# Altium schematic sheet [5] Peripheral_Module.SchDoc("[5] Peripheral_Module")
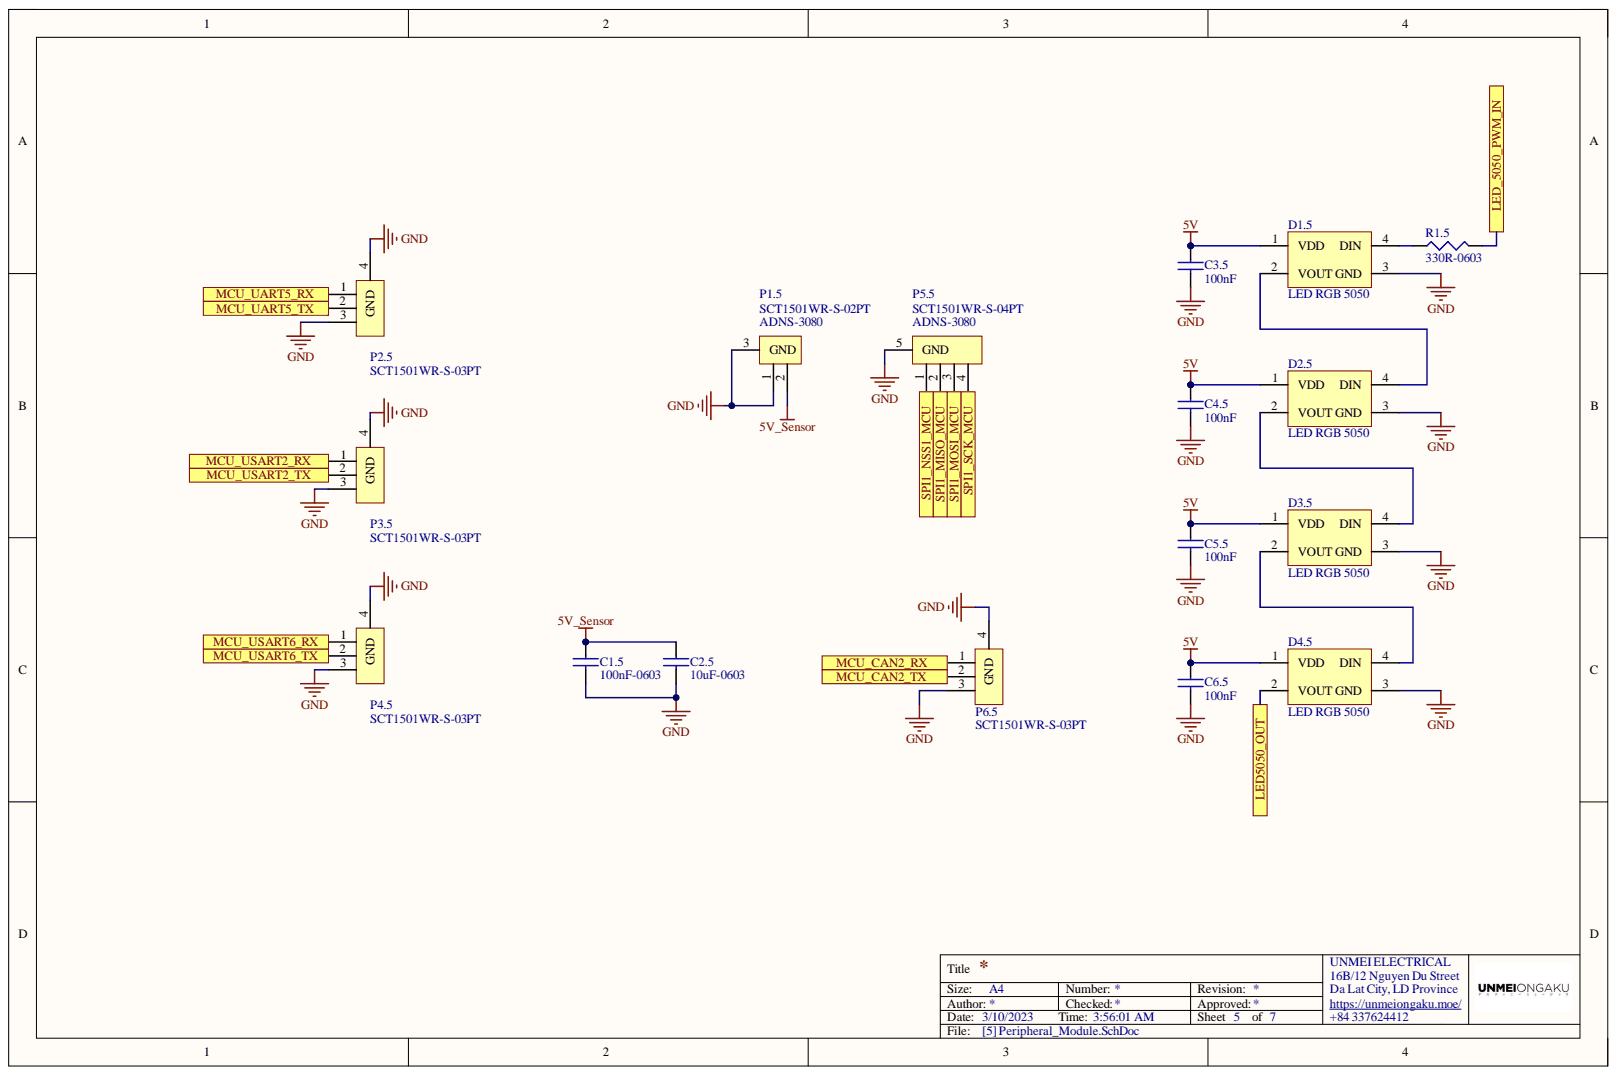
[diagram p0: [5] Peripheral_Module.SchDoc]

## Parts
C1.5  100nF-0603  [CAP0603]
C2.5  10uF-0603  [CAP0603]
C3.5  100nF  [CAP0603]
C4.5  100nF  [CAP0603]
C5.5  100nF  [CAP0603]
C6.5  100nF  [CAP0603]
D1.5  LED RGB 5050  [LED RGB  5050]
D2.5  LED RGB 5050  [LED RGB  5050]
D3.5  LED RGB 5050  [LED RGB  5050]
D4.5  LED RGB 5050  [LED RGB  5050]
P1.5  SCT1501WR-S-02PT  [Connector ZH1.5 2P SMD]
P2.5  SCT1501WR-S-03PT  [Connector ZH1.5 3P SMD]
P3.5  SCT1501WR-S-03PT  [Connector ZH1.5 3P SMD]
P4.5  SCT1501WR-S-03PT  [Connector ZH1.5 3P SMD]
P5.5  SCT1501WR-S-04PT  [Connector ZH1.5 4P SMD]
P6.5  SCT1501WR-S-03PT  [Connector ZH1.5 3P SMD]
R1.5  330R-0603  [RES0603 - 3D]

## Ports
LED5050_OUT, LED_5050_PWM_IN, MCU_CAN2_RX, MCU_CAN2_TX, MCU_UART5_RX, MCU_UART5_TX, MCU_USART2_RX, MCU_USART2_TX, MCU_USART6_RX, MCU_USART6_TX, SPI1_MISO_MCU, SPI1_MOSI_MCU, SPI1_NSS1_MCU, SPI1_SCK_MCU

## Nets
5V: C3.5.1 C4.5.1 C5.5.1 C6.5.1 D1.5.1(VDD) D2.5.1(VDD) D3.5.1(VDD) D4.5.1(VDD)
5V_Sensor: C1.5.1 C2.5.1 P1.5.2
GND: C1.5.2 C2.5.2 C3.5.2 C4.5.2 C5.5.2 C6.5.2 D1.5.3(GND) D2.5.3(GND) D3.5.3(GND) D4.5.3(GND) P1.5.1 P1.5.3 P2.5.3 P2.5.4(GND) P3.5.3 P3.5.4(GND) P4.5.3 P4.5.4(GND) P5.5.5(GND) P6.5.3 P6.5.4(GND)
LED5050_OUT: D4.5.2(VOUT) ; port LED5050_OUT
LED_5050_PWM_IN: R1.5.2 ; port LED_5050_PWM_IN
MCU_CAN2_RX: P6.5.1 ; port MCU_CAN2_RX
MCU_CAN2_TX: P6.5.2 ; port MCU_CAN2_TX
MCU_UART5_RX: P2.5.1 ; port MCU_UART5_RX
MCU_UART5_TX: P2.5.2 ; port MCU_UART5_TX
MCU_USART2_RX: P3.5.1 ; port MCU_USART2_RX
MCU_USART2_TX: P3.5.2 ; port MCU_USART2_TX
MCU_USART6_RX: P4.5.1 ; port MCU_USART6_RX
MCU_USART6_TX: P4.5.2 ; port MCU_USART6_TX
SPI1_MISO_MCU: P5.5.2 ; port SPI1_MISO_MCU
SPI1_MOSI_MCU: P5.5.3 ; port SPI1_MOSI_MCU
SPI1_NSS1_MCU: P5.5.1 ; port SPI1_NSS1_MCU
SPI1_SCK_MCU: P5.5.4 ; port SPI1_SCK_MCU
NetD1.5_2: D1.5.2(VOUT) D2.5.4(DIN)
NetD1.5_4: D1.5.4(DIN) R1.5.1
NetD2.5_2: D2.5.2(VOUT) D3.5.4(DIN)
NetD3.5_2: D3.5.2(VOUT) D4.5.4(DIN)

## Library data of the parts
C1.5 = CC0603KRX7R9BB104: CAP CER 0.1UF 50V X7R 0603; Manufacturer 1: Yageo; Capacitance: 0.1µF; Category 1: Capacitors; Tolerance: ±10%; Value: 100nF; Applications: General Purpose; Component Kind: Standard; ComponentLink1Description: http://www.yageo.com/documents/recent/UPY-GPHC_X7R_6.3V-to-50V_18.pdf; ComponentLink1URL: http://www.yageo.com/documents/recent/UPY-GPHC_X7R_6.3V-to-50V_18.pdf; Description 1: CAP CER 0.1UF 50V X7R 0603; Failure Rate: -; Features: -; Height - Seated (Max): -; Lead Spacing: -; Lead Style: -; Manufacturer Part Number 1: CC0603KRX7R9BB104; Mounting Type: Surface Mount, MLCC; Operating Temperature: -55°C ~ 125°C; Package / Case: 0603 (1608 Metric); Packaging: Cut Tape (CT); Part Status: Active; Pricing 1: 1=0.1, 10=0.031, 100=0.014, 500=0.00998, 1000=0.00783 (USD); Ratings: -; RoHS 1: RoHS Compliant; Size / Dimension: 0.063" L x 0.031" W (1.60mm x 0.80mm); Stock 1: 4411645; Supplier 1: Digi-Key; Supplier Part Number 1: 311-1344-1-ND; Temperature Coefficient: X7R; Thickness (Max): 0.035" (0.90mm); Voltage - Rated: 50V; Library Name: Minh_Lib.SchLib
C2.5 = CC0603KRX5R6BB106: CAP CER 10UF 10V X5R 0603; Manufacturer 1: Yageo; Capacitance: 10µF; Category 1: Capacitors; Tolerance: ±10%; Applications: General Purpose; Component Kind: Standard; ComponentLink1Description: http://www.yageo.com/documents/recent/UPY-GPHC_X5R_4V-to-50V_24.pdf; ComponentLink1URL: http://www.yageo.com/documents/recent/UPY-GPHC_X5R_4V-to-50V_24.pdf; Description 1: CAP CER 10UF 10V X5R 0603; Failure Rate: -; Features: -; Height - Seated (Max): -; Lead Spacing: -; Lead Style: -; Manufacturer Part Number 1: CC0603KRX5R6BB106; Mounting Type: Surface Mount, MLCC; Operating Temperature: -55°C ~ 85°C; Package / Case: 0603 (1608 Metric); Packaging: Cut Tape (CT); Part Status: Active; Pricing 1: 1=0.33, 10=0.225, 100=0.1272, 500=0.08982, 1000=0.07858 (USD); Ratings: -; RoHS 1: RoHS Compliant; Size / Dimension: 0.063" L x 0.031" W (1.60mm x 0.80mm); Stock 1: 66566; Supplier 1: Digi-Key; Supplier Part Number 1: 311-1782-1-ND; Temperature Coefficient: X5R; Thickness (Max): 0.035" (0.90mm); Value: 10uF; Voltage - Rated: 10V; Library Name: Minh_Lib.SchLib
C3.5 C4.5 C5.5 C6.5 = CC0603KRX7R0BB104: CAP CER 0.1UF 100V X7R 0603; Manufacturer: Yageo; Tolerance: ±10%; Applications: General Purpose; Category: Capacitors; Component Kind: Standard; ComponentLink1Description: http://media.digikey.com/pdf/Data%20Sheets/Yageo%20PDFs/NPO,%20X7R%20Mid-voltage.pdf; ComponentLink1URL: http://media.digikey.com/pdf/Data%20Sheets/Yageo%20PDFs/NPO,%20X7R%20Mid-voltage.pdf; Failure Rate: -; Features: -; Height - Seated (Max): -; Lead Spacing: -; Lead Style: -; Manufacturer Part Number: CC0603KRX7R0BB104; Mounting Type: Surface Mount, MLCC; Operating Temperature: -55°C ~ 125°C; Package / Case: 0603 (1608 Metric); Packaging: Cut Tape (CT); Part Status: Active; Pricing 1: 1=0.23, 10=0.156, 100=0.0791, 500=0.05414, 1000=0.0479 (USD); Ratings: -; RoHS: RoHS Compliant; Size / Dimension: 0.063" L x 0.032" W (1.60mm x 0.80mm); Stock 1: 784508; Supplier 1: Digi-Key; Supplier Part Number 1: 311-1523-1-ND; Temperature Coefficient: X7R; Thickness (Max): 0.035" (0.90mm); Value: 100nF; Voltage - Rated: 100V; Library Name: Minh_Lib.SchLib
D1.5 D2.5 D3.5 D4.5 = LED RBR 5050 - IN-PI55QATPRPGPBPW-60: Address Led Discrete Serial Rgbw; Manufacturer: Inolux; Color: White,Blue,Green,Red; Dominant Wavelength: 465nm; Forward Voltage: 5.2V; Lens Style: Round,Flat; Luminous Intensity: 1850mcd; Test Current: 12mA; Case/Package: SMD/SMT; Category: LEDs; Component Kind: Standard; ComponentLink1Description: http://www.inolux-corp.com/datasheet/SMDLED/Addressable%20LED/IN-PI55QATPRPGPBPW-XX_v1.2.pdf?src-supplier=Digi-Key; ComponentLink1URL: http://www.inolux-corp.com/datasheet/SMDLED/Addressable%20LED/IN-PI55QATPRPGPBPW-XX_v1.2.pdf?src-supplier=Digi-Key; Height: 1.7mm; Length: 5mm; Manufacturer Part Number: IN-PI55QATPRPGPBPW-60; Mount: Surface Mount; Packaging: Tape and Reel; Pricing 1: 1=0.71450, 10=0.46990, 25=0.46520, 100=0.30470, 250=0.30220, 500=0.29430 (USD); RoHS: No; Stock 1: 964; Supplier 1: Arrow Electronics; Supplier Part Number 1: IN-PI55QATPRPGPBPW-60; Width: 5mm; Library Name: Minh_Lib.SchLib
R1.5 = RC0603JR-07330RL: RES SMD 330 OHM 5% 1/10W 0603; Manufacturer 1: Yageo; Category 1: Resistors; Power (Watts): 0.1W, 1/10W; Resistance (Ohms): 330; Temperature Coefficient: ±100ppm/°C; Value: 330R; Component Kind: Standard; ComponentLink1Description: http://www.yageo.com/documents/recent/PYu-RC_Group_51_RoHS_L_7.pdf; ComponentLink1URL: http://www.yageo.com/documents/recent/PYu-RC_Group_51_RoHS_L_7.pdf; Composition: Thick Film; Description 1: RES SMD 330 OHM 5% 1/10W 0603; Failure Rate: -; Features: Moisture Resistant; Height - Seated (Max): 0.022" (0.55mm); Manufacturer Part Number 1: RC0603JR-07330RL; Operating Temperature: -55°C ~ 155°C; Package / Case: 0603 (1608 Metric); Packaging: Cut Tape (CT); Part Status: Active; Pricing 1: 1=0.1, 10=0.011, 100=0.0046, 1000=0.00207, 2500=0.0018 (USD); RoHS 1: RoHS Compliant; Size / Dimension: 0.063" L x 0.031" W (1.60mm x 0.80mm); Stock 1: 1463213; Supplier 1: Digi-Key; Supplier Device Package: 0603; Supplier Part Number 1: 311-330GRCT-ND; Tolerance: ±5%; Library Name: Minh_Lib.SchLib
P1.5 = SCT1501WR-S-02PT: Component Kind: Standard; Library Name: Minh_Lib.SchLib
P2.5 P3.5 P4.5 P6.5 = SCT1501WR-S-03PT: Component Kind: Standard; Library Name: Minh_Lib.SchLib
P5.5 = SCT1501WR-S-04PT: Component Kind: Standard; Library Name: Minh_Lib.SchLib
